# Altium schematic sheet main.SchDoc(main)
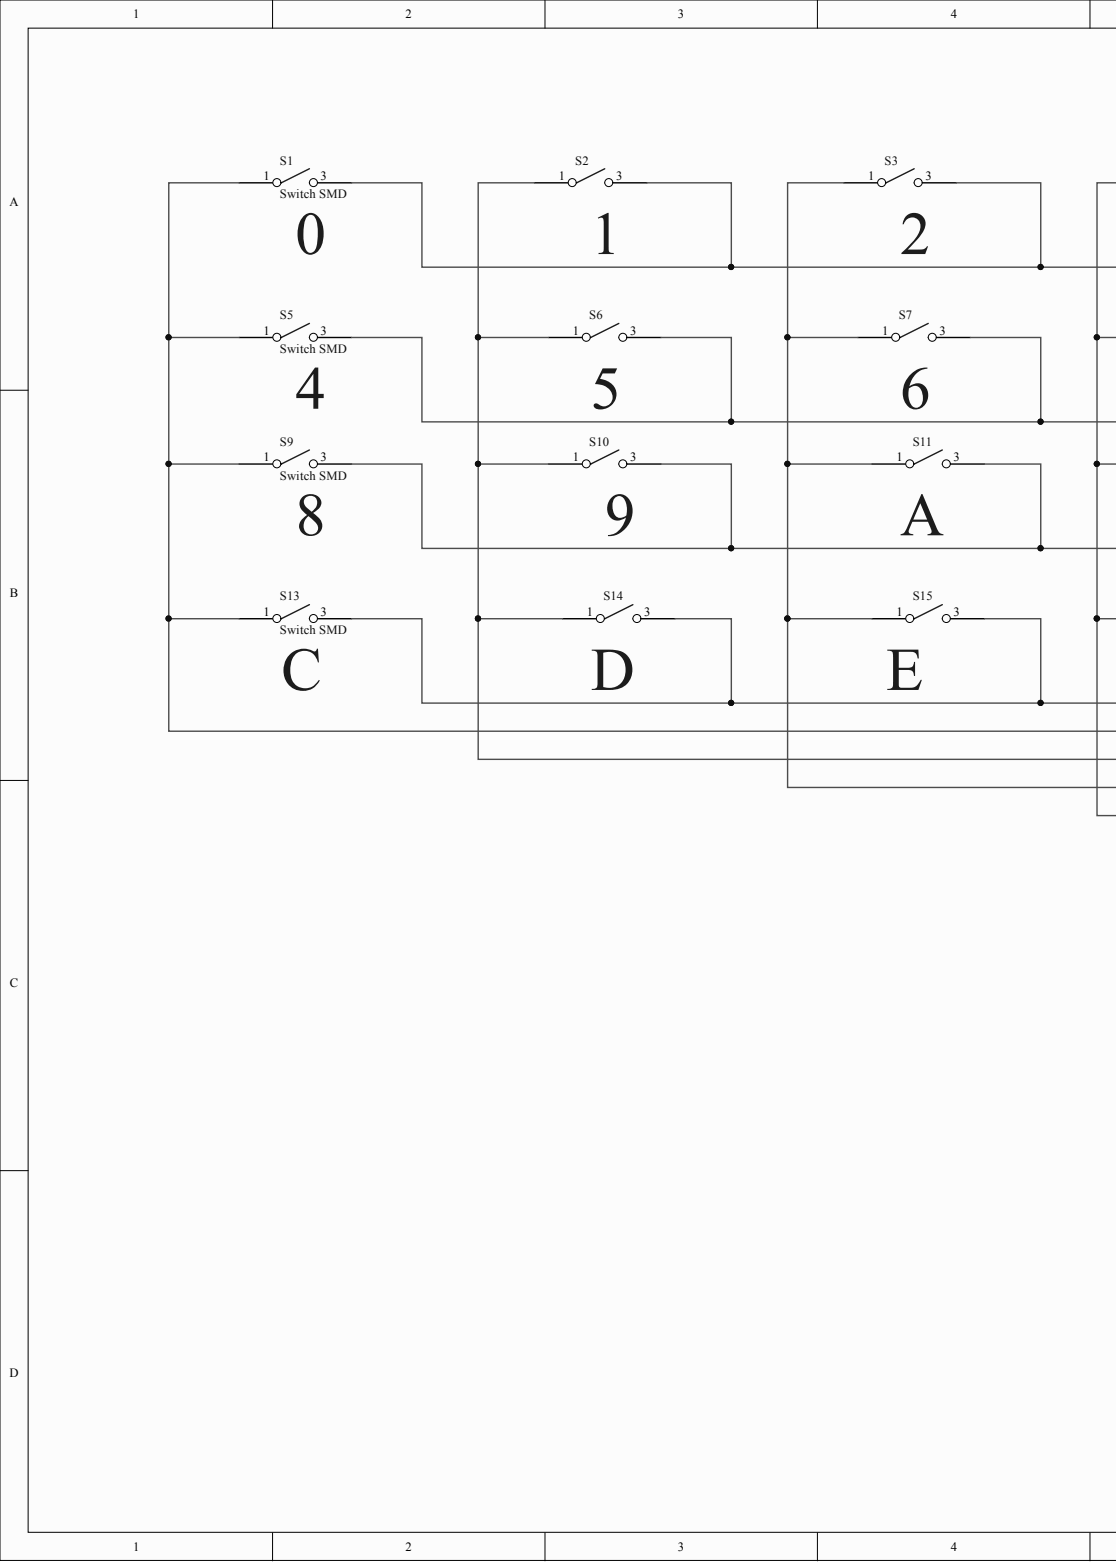
[diagram p0: main.SchDoc, left]
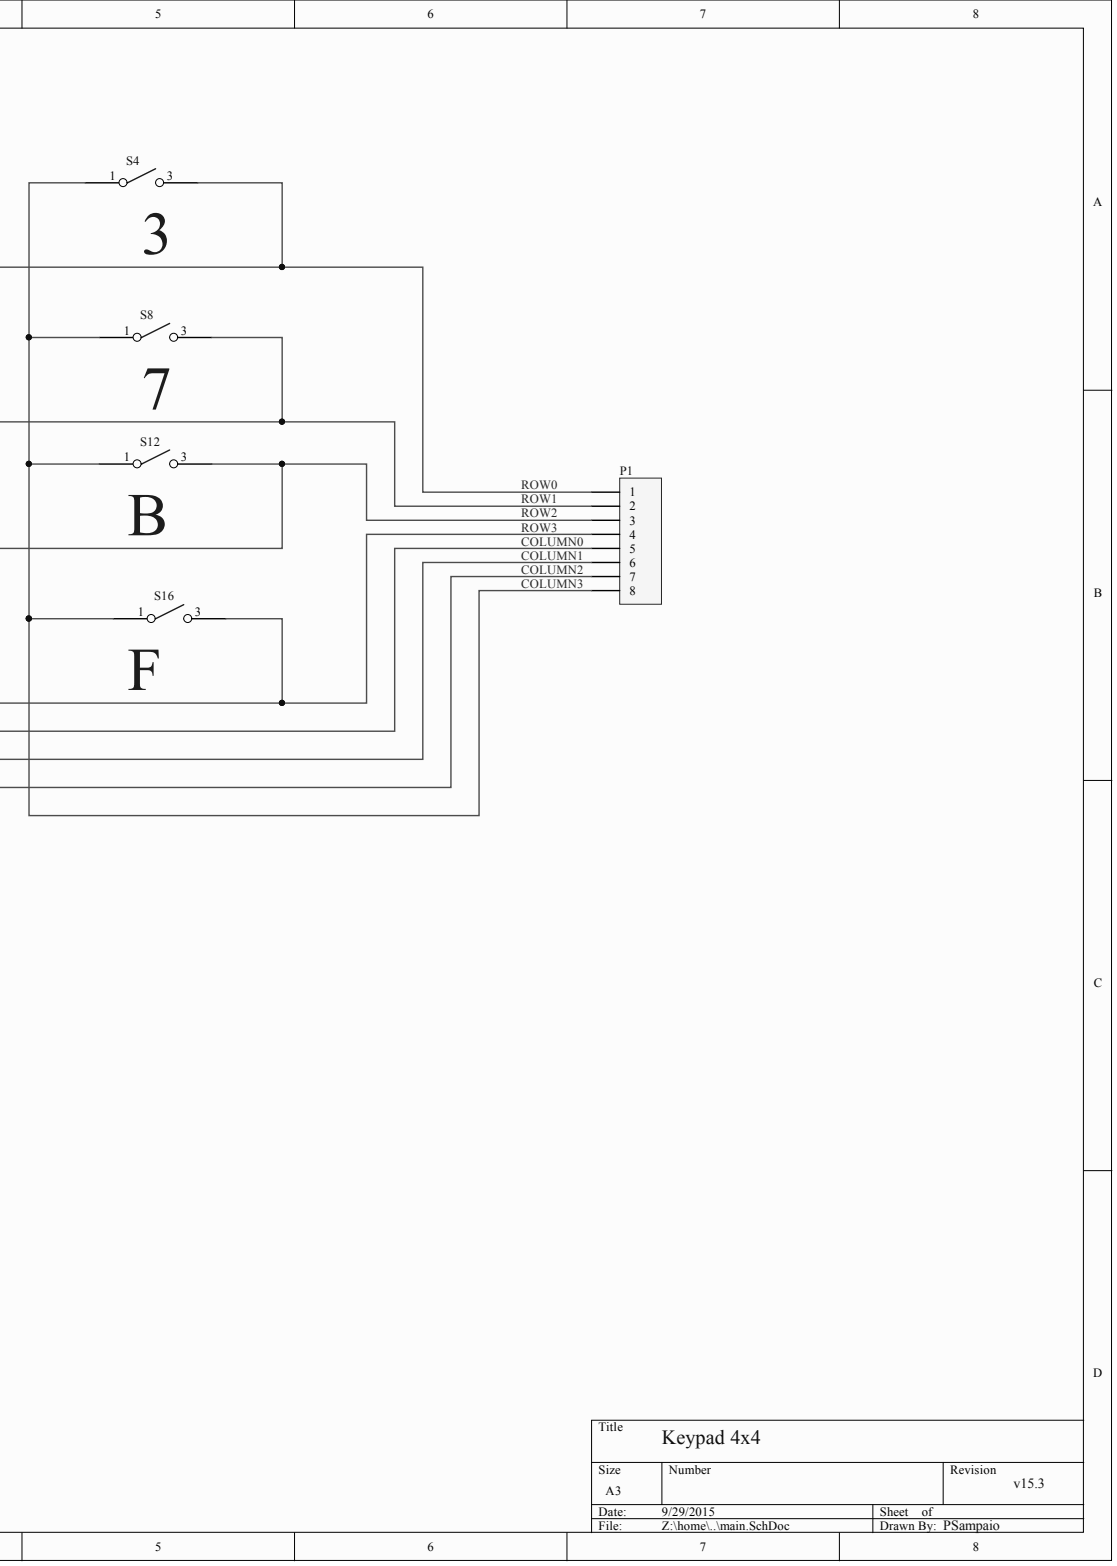
[diagram p1: main.SchDoc, right]

## Parts
P1  Header 8  [HDR1X8]  (value not drawn)
S1  Switch SMD  [B3FS]
S2  Switch SMD  [B3FS]
S3  Switch SMD  [B3FS]
S4  Switch SMD  [B3FS]
S5  Switch SMD  [B3FS]
S6  Switch SMD  [B3FS]
S7  Switch SMD  [B3FS]
S8  Switch SMD  [B3FS]
S9  Switch SMD  [B3FS]
S10  Switch SMD  [B3FS]
S11  Switch SMD  [B3FS]
S12  Switch SMD  [B3FS]
S13  Switch SMD  [B3FS]
S14  Switch SMD  [B3FS]
S15  Switch SMD  [B3FS]
S16  Switch SMD  [B3FS]

## Nets
COLUMN0: P1.5 S1.1 S5.1 S9.1 S13.1
COLUMN1: P1.6 S2.1 S6.1 S10.1 S14.1
COLUMN2: P1.7 S3.1 S7.1 S11.1 S15.1
COLUMN3: P1.8 S4.1 S8.1 S12.1 S16.1
ROW0: P1.1 S1.3 S2.3 S3.3 S4.3
ROW1: P1.2 S5.3 S6.3 S7.3 S8.3
ROW2: P1.3 S9.3 S10.3 S11.3 S12.3
ROW3: P1.4 S13.3 S14.3 S15.3 S16.3

## Library data of the parts
P1 = Header 8: Header, 8-Pin; Component Kind: Standard; LatestRevisionDate: 17-Jul-2002; LatestRevisionNote: Re-released for DXP Platform.; Library Name: Miscellaneous Connectors.IntLib; Signal Integrity: Connector; Publisher: Altium Limited
S1-S16 = B3FS: Tactile Switch 6x6mm; Component Kind: Standard; Library Name: LibComponents.IntLib
  S3: Supplier 1: Mouser; Supplier Part Number 1: 653-B3FS-1000P
